# Altium schematic sheet Schematic Prints("All Documents",Physical)
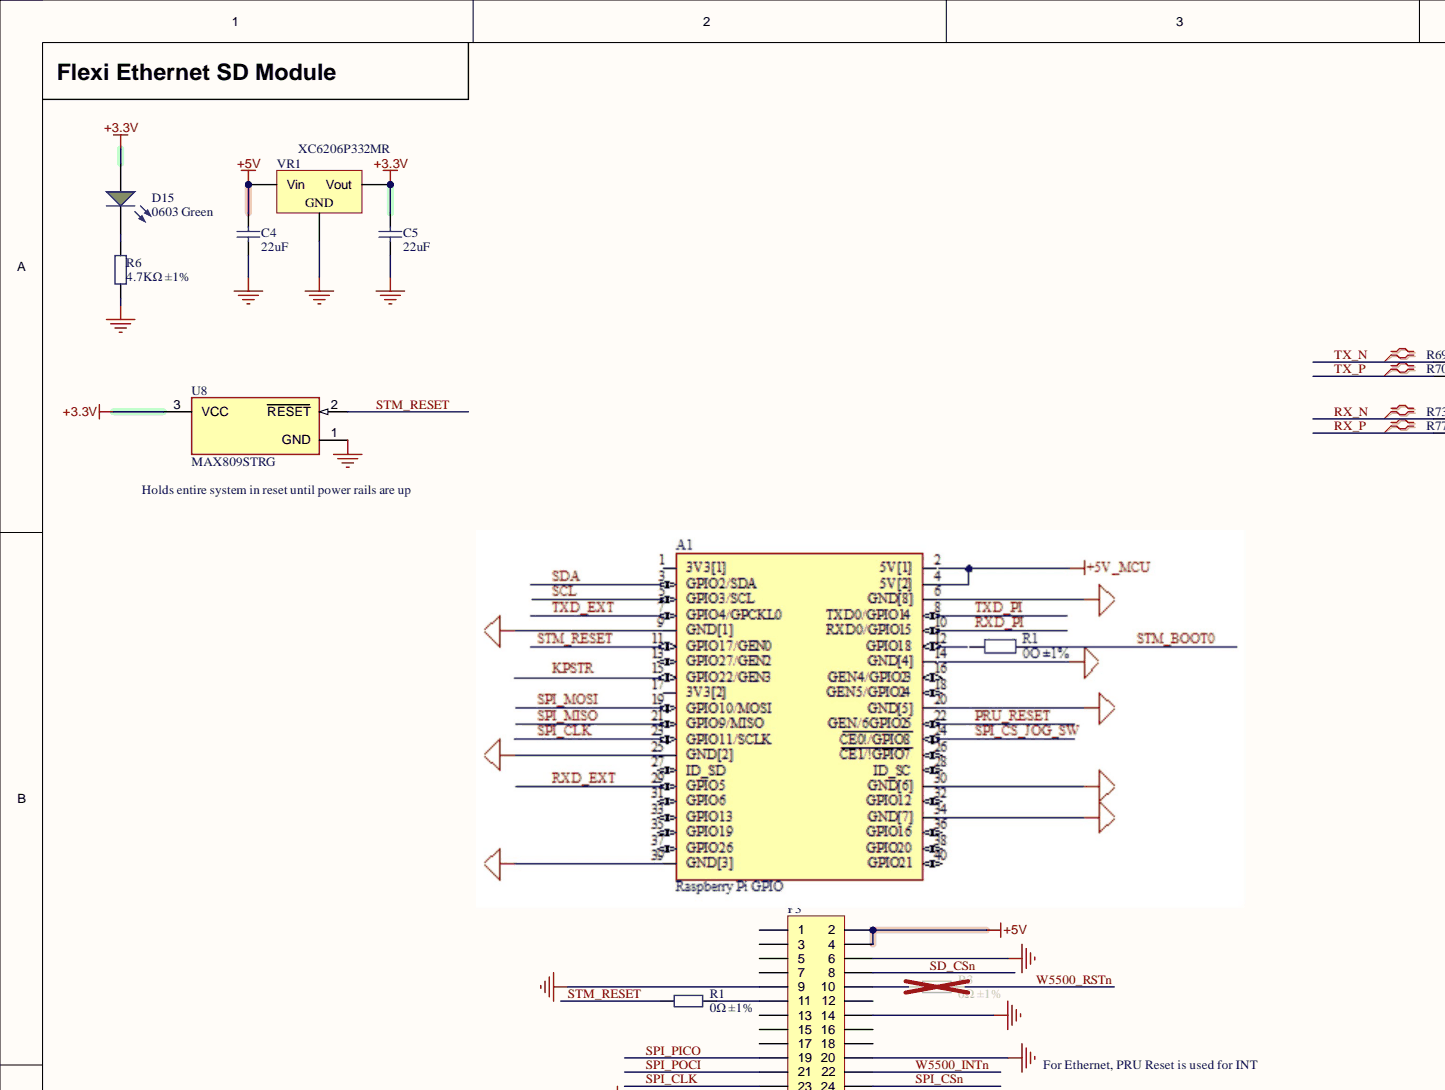
[diagram p0: Schematic Prints("All Documents",Physical), top left]
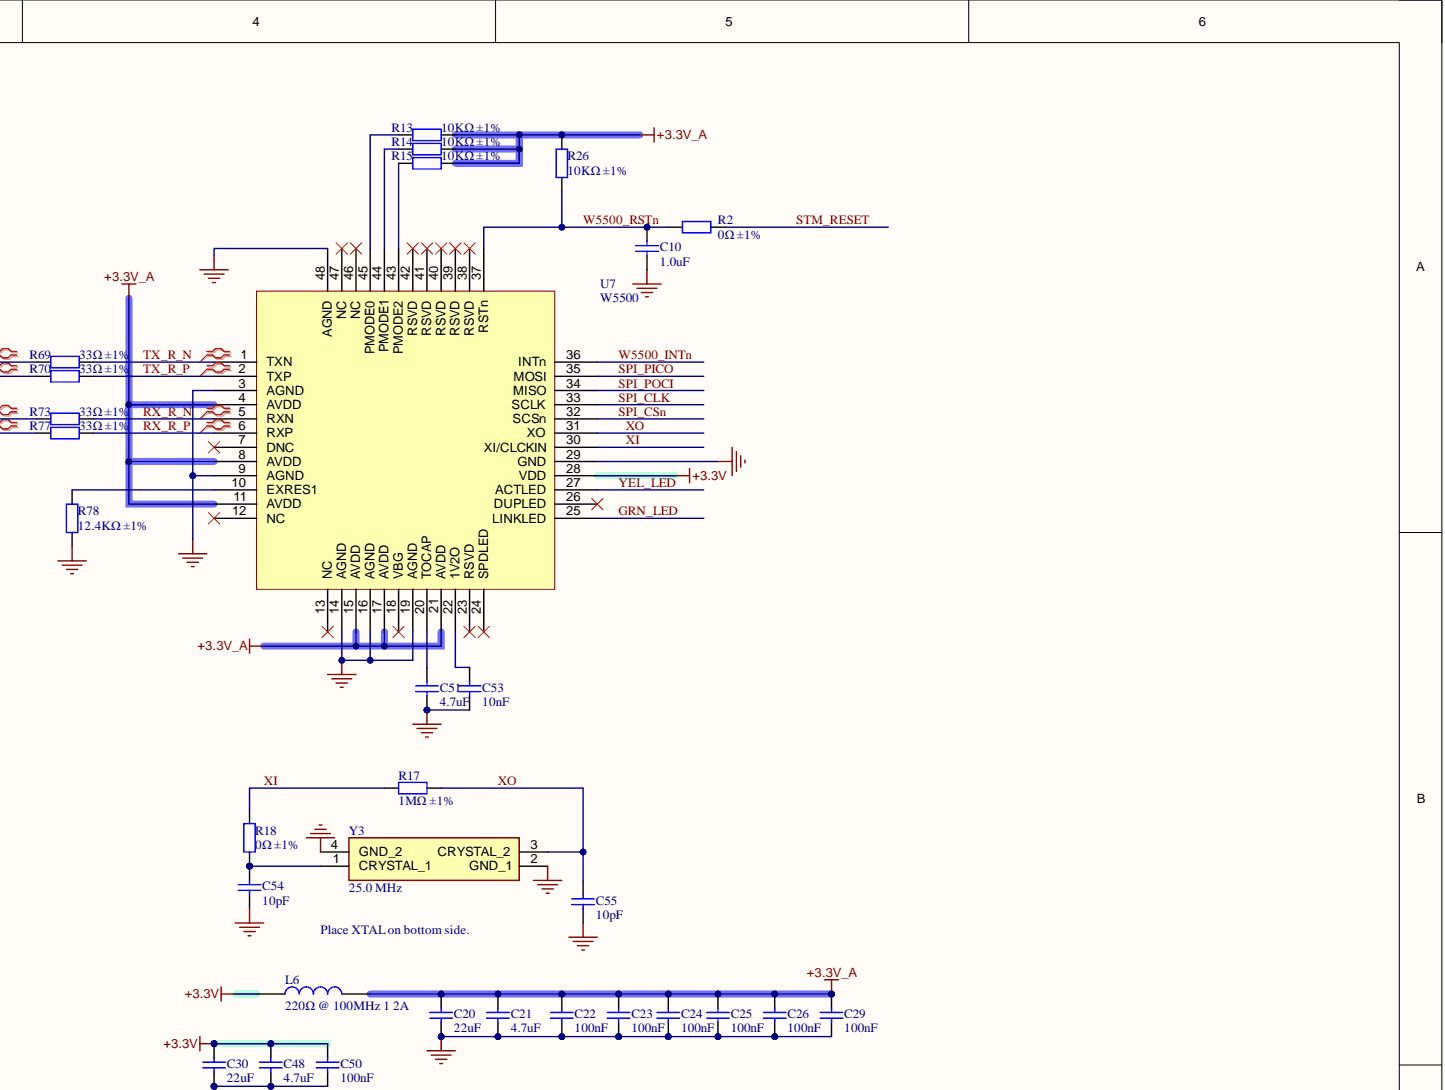
[diagram p1: Schematic Prints("All Documents",Physical), top right]
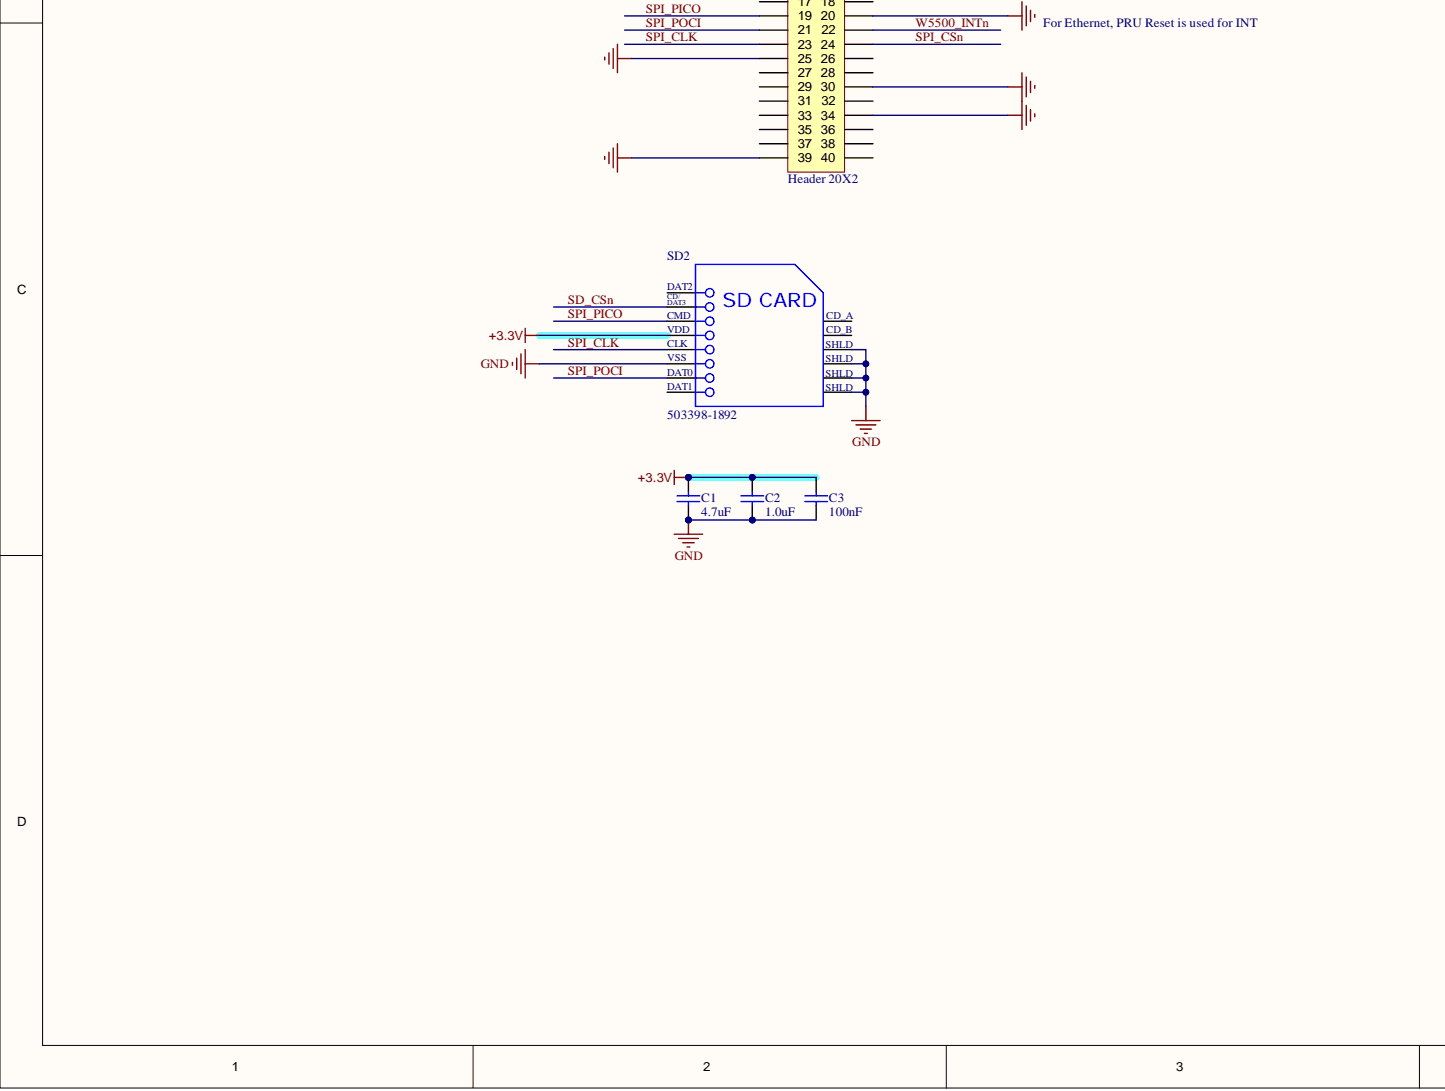
[diagram p2: Schematic Prints("All Documents",Physical), bottom left]
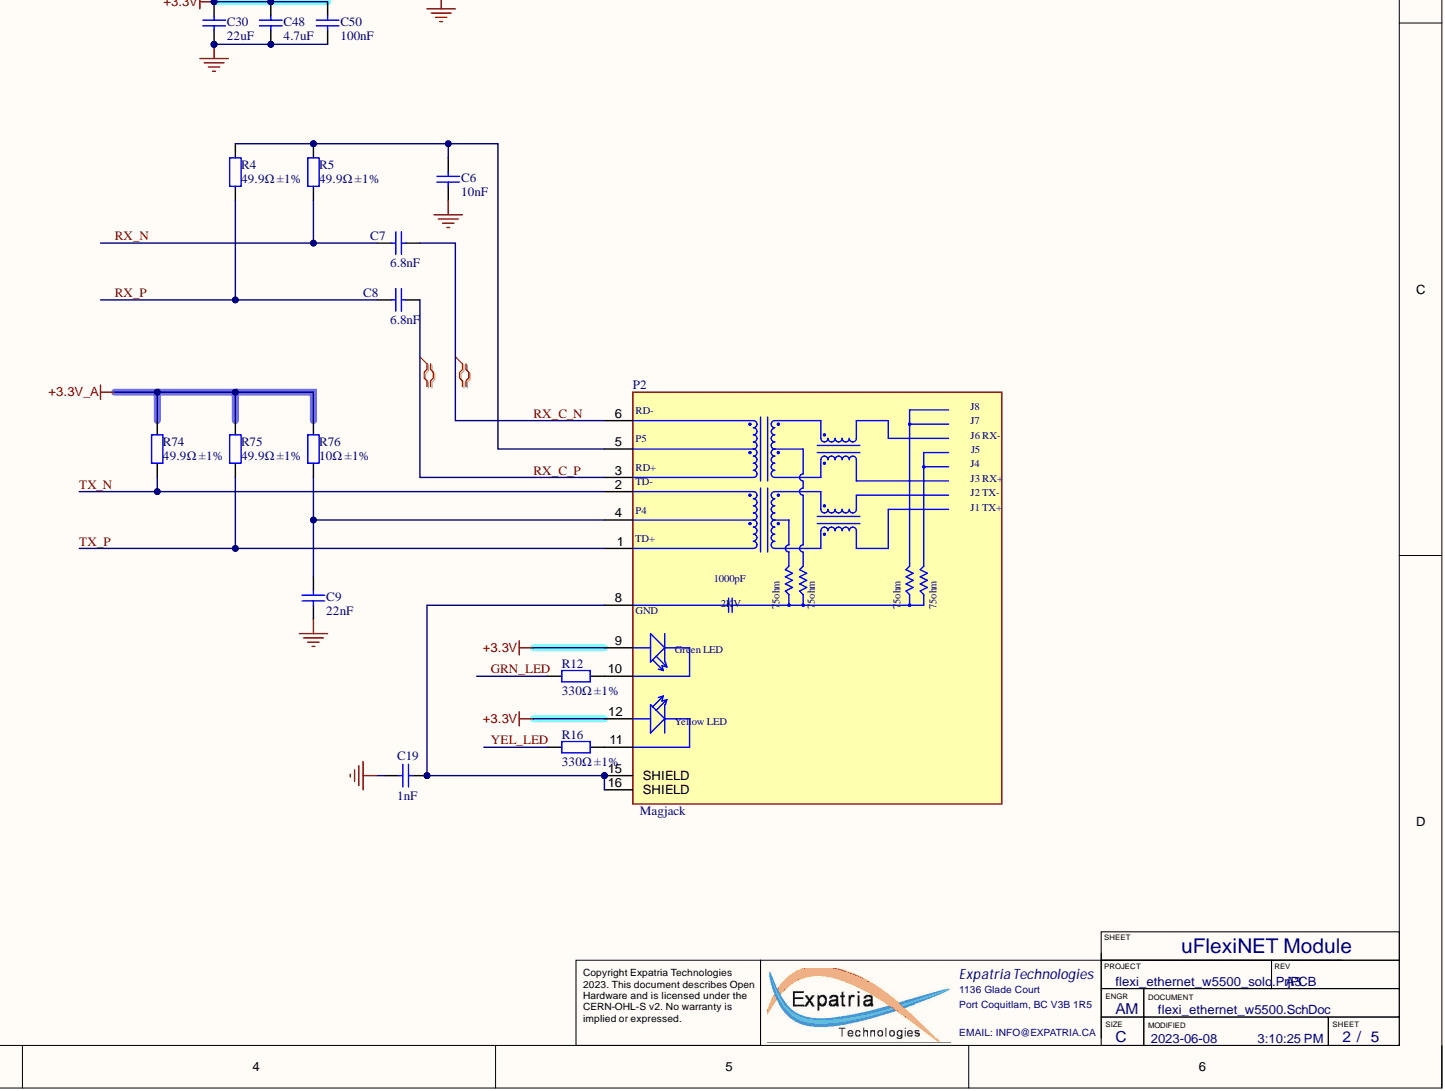
[diagram p3: Schematic Prints("All Documents",Physical), bottom right]

## Parts
C1  4.7uF  [0603_C]
C2  1.0uF  [0402_C]
C3  100nF  [0402_C]
C4  22uF  [0805_C]
C5  22uF  [0805_C]
C6  10nF  [0402_C]
C7  6.8nF  [0402_C]
C8  6.8nF  [0402_C]
C9  22nF  [0402_C]
C10  1.0uF  [0402_C]
C19  1nF  [1206_C]
C20  22uF  [0805_C]
C21  4.7uF  [0603_C]
C22  100nF  [0402_C]
C23  100nF  [0402_C]
C24  100nF  [0402_C]
C25  100nF  [0402_C]
C26  100nF  [0402_C]
C29  100nF  [0402_C]
C30  22uF  [0805_C]
C48  4.7uF  [0603_C]
C50  100nF  [0402_C]
C51  4.7uF  [0402_C]
C53  10nF  [0402_C]
C54  10pF  [0402_C]
C55  10pF  [0402_C]
D15  0603 Green  Color: Green  Package: 0603  [0603_LED_S1]
L6  220Ω @ 100MHz 1 2A  [0805_C]
P2  Magjack  [HANRUN_HR911105A]
P3  Header 20X2  [HDR2X20_2.54_180]
R1  0Ω ±1%  Resistance: 0Ω  [0402_R]
R2  0Ω ±1%  Resistance: 0Ω  [0402_R]
R3  0Ω ±1%  Resistance: 0Ω  [0402_R]
R4  49.9Ω ±1%  Resistance: 49.9Ω  [0402_R]
R5  49.9Ω ±1%  Resistance: 49.9Ω  [0402_R]
R6  4.7KΩ ±1%  Resistance: 4.7KΩ  [0402_R]
R12  330Ω ±1%  Resistance: 330Ω  [0402_R]
R13  10KΩ ±1%  Resistance: 10KΩ  [0402_R]
R14  10KΩ ±1%  Resistance: 10KΩ  [0402_R]
R15  10KΩ ±1%  Resistance: 10KΩ  [0402_R]
R16  330Ω ±1%  Resistance: 330Ω  [0402_R]
R17  1MΩ ±1%  Resistance: 1MΩ  [0402_R]
R18  0Ω ±1%  Resistance: 0Ω  [0402_R]
R26  10KΩ ±1%  Resistance: 10KΩ  [0402_R]
R69  33Ω ±1%  Resistance: 33Ω  [0402_R]
R70  33Ω ±1%  Resistance: 33Ω  [0402_R]
R73  33Ω ±1%  Resistance: 33Ω  [0402_R]
R74  49.9Ω ±1%  Resistance: 49.9Ω  [0402_R]
R75  49.9Ω ±1%  Resistance: 49.9Ω  [0402_R]
R76  10Ω ±1%  Resistance: 10Ω  [0402_R]
R77  33Ω ±1%  Resistance: 33Ω  [0402_R]
R78  12.4KΩ ±1%  [0603_R]
SD2  503398-1892  [MOLX-503398-1892_V]
U7  W5500  [LQFP-48_7x7x05P]
U8  MAX809STRG  [FP-318-08-MFG]
VR1  XC6206P332MR  [SOT-23-3L]
Y3  25.0 MHz  [YSX321SL]

## Nets
+3.3V: C1.2 C2.2 C3.2 C5.2 C30.2 C48.2 C50.2 D15.A L6.1 P2.9 P2.12 SD2.4 U7.28(VDD) U8.3 VR1.2
+3.3V_A: C20.2 C21.2 C22.2 C23.2 C24.2 C25.2 C26.2 C29.2 L6.2 R13.1 R14.1 R15.1 R26.1 R74.1 R75.1 R76.1 U7.4(AVDD) U7.8(AVDD) U7.11(AVDD) U7.15(AVDD) U7.17(AVDD) U7.21(AVDD)
+5V: C4.2 P3.2 P3.4 VR1.3
GND: C1.1 C2.1 C3.1 C4.1 C5.1 C6.1 C9.1 C10.1 C19.1 C20.1 C21.1 C22.1 C23.1 C24.1 C25.1 C26.1 C29.1 C30.1 C48.1 C50.1 C51.1 C53.1 C54.1 C55.1 P3.6 P3.9 P3.14 P3.20 P3.25 P3.30 P3.34 P3.39 R6.1 R78.1 SD2.6 SD2.11 SD2.12 SD2.13 SD2.14 U7.3(AGND) U7.9(AGND) U7.14(AGND) U7.16(AGND) U7.19(AGND) U7.29(GND) U7.48(AGND) U8.1 VR1.1 Y3.2(GND_1) Y3.4(GND_2)
GRN_LED: R12.1 U7.25(LINKLED)
RX_C_N: C7.1 P2.6
RX_C_P: C8.1 P2.3
RX_N: C7.2 R5.2 R73.1
RX_P: C8.2 R4.2 R77.1
RX_R_N: R73.2 U7.5(RXN)
RX_R_P: R77.2 U7.6(RXP)
SD_CSn: P3.8 SD2.2(SD_CARD)
SPI_CLK: P3.23 SD2.5 U7.33(SCLK)
SPI_CSn: P3.24 U7.32(SCSn)
SPI_PICO: P3.19 SD2.3 U7.35(MOSI)
SPI_POCI: P3.21 SD2.7 U7.34(MISO)
STM_RESET: R1.2 R2.1 U8.2
TX_N: P2.2 R69.1 R74.2
TX_P: P2.1 R70.1 R75.2
TX_R_N: R69.2 U7.1(TXN)
TX_R_P: R70.2 U7.2(TXP)
W5500_INTn: P3.22 U7.36(INTn)
W5500_RSTn: C10.2 R2.2 R3.1 R26.2 U7.37(RSTn)
XI: R17.1 R18.1 U7.30(XI/CLCKIN)
XO: C55.2 R17.2 U7.31(XO) Y3.3(CRYSTAL_2)
YEL_LED: R16.1 U7.27(ACTLED)
NetC6_2: C6.2 P2.5 R4.1 R5.1
NetC9_2: C9.2 P2.4 R76.2
NetC19_2: C19.2 P2.8 P2.15(SHIELD) P2.16(SHIELD)
NetC51_2: C51.2 U7.20(TOCAP)
NetC53_2: C53.2 U7.22(1V2O)
NetC54_2: C54.2 R18.2 Y3.1(CRYSTAL_1)
NetD15_K: D15.K R6.2
NetP2_10: P2.10 R12.2
NetP2_11: P2.11 R16.2
NetP3_1: P3.1
NetP3_3: P3.3
NetP3_5: P3.5
NetP3_7: P3.7
NetP3_10: P3.10 R3.2
NetP3_11: P3.11 R1.1
NetP3_12: P3.12
NetP3_13: P3.13
NetP3_15: P3.15
NetP3_16: P3.16
NetP3_17: P3.17
NetP3_18: P3.18
NetP3_26: P3.26
NetP3_27: P3.27
NetP3_28: P3.28
NetP3_29: P3.29
NetP3_31: P3.31
NetP3_32: P3.32
NetP3_33: P3.33
NetP3_35: P3.35
NetP3_36: P3.36
NetP3_37: P3.37
NetP3_38: P3.38
NetP3_40: P3.40
NetR13_2: R13.2 U7.45(PMODE0)
NetR14_2: R14.2 U7.44(PMODE1)
NetR15_2: R15.2 U7.43(PMODE2)
NetR78_2: R78.2 U7.10(EXRES1)
NetSD2_1: SD2.1
NetSD2_8: SD2.8
NetSD2_9: SD2.9
NetSD2_10: SD2.10
NetU7_7: U7.7(DNC)
NetU7_12: U7.12(NC)
NetU7_13: U7.13(NC)
NetU7_18: U7.18(VBG)
NetU7_23: U7.23(RSVD)
NetU7_24: U7.24(SPDLED)
NetU7_26: U7.26(DUPLED)
NetU7_38: U7.38(RSVD)
NetU7_39: U7.39(RSVD)
NetU7_40: U7.40(RSVD)
NetU7_41: U7.41(RSVD)
NetU7_42: U7.42(RSVD)
NetU7_46: U7.46(NC)
NetU7_47: U7.47(NC)

## Library data of the parts
C1 = 0603 4.7uF (475) 10% 16V: Chip capacitors; Manufacturer: Samsung Electro-Mechanics; Manufacturer Part Number: CL10A475KO8NNNC; Tolerance: 10%; Voltage: 16V; Capacitance: 4.7uF; Component Kind: Standard; ComponentLink1Description: Supplier link; ComponentLink1URL: http://www.szlcsc.com/product/details_20375.html; Library Name: Cap.SchLib; Package: 0603; PartNumber: CL10A475KO8NNNC; Supplier: LCSC; SuppliersPartNumber: C19666
C2 C10 = 0402 1.0uF (105) 10% 25V: Chip capacitor; Manufacturer: Samsung Electro-Mechanics; PartNumber: CL05A105KA5NQNC; Capacitance: 1uF; Tolerance: 10%; Voltage: 25V; Component Kind: Standard; ComponentLink1Description: Supplier link; ComponentLink1URL: http://www.szlcsc.com/product/details_53938.html; Library Name: Cap.SchLib; Package: 0402; Supplier: LC; SuppliersPartNumber: C52923
C3 C22-C26 C29 C50 = 0402 100nF (104) 10% 16V: Chip capacitors; Manufacturer: Samsung Electro-Mechanics; PartNumber: CL05B104KO5NNNC; Tolerance: 10%; Voltage: 16V; Capacitance: 100nF; Component Kind: Standard; ComponentLink1Description: Supplier link; ComponentLink1URL: http://www.szlcsc.com/product/details_1877.html; Library Name: Cap.SchLib; Package: 0402; Supplier: LC; SuppliersPartNumber: C1525
C4 C5 C20 C30 = 0805 22uF (226) 20% 25V: Chip capacitors; Manufacturer: Samsung Electro-Mechanics; PartNumber: CL21A226MAQNNNE; Tolerance: 20%; Voltage: 25V; Capacitance: 22uF; Component Kind: Standard; ComponentLink1Description: Supplier link; ComponentLink1URL: http://www.szlcsc.com/product/details_46786.html; Library Name: Cap.SchLib; Package: 0805; Supplier: LC; SuppliersPartNumber: C2922481
C6 C53 = 0402 10nF (103) 10% 50V: Chip capacitors; Manufacturer: Samsung Electro-Mechanics; PartNumber: CL05B103KB5NNNC; Tolerance: 10%; Voltage: 50V; Capacitance: 10nF; Component Kind: Standard; ComponentLink1Description: Supplier link; ComponentLink1URL: http://www.szlcsc.com/product/details_15869.html; Library Name: Cap.SchLib; Package: 0402; Supplier: LC; SuppliersPartNumber: C15195
C7 C8 = 0402 6.8nF (682) 10% 50V: Chip capacitors; Manufacturer: Guangdong Fenghua Advanced Tech; PartNumber: 0402B682K500NT; Tolerance: 10%; Voltage: 50V; Capacitance: 6.8nF; Component Kind: Standard; ComponentLink1Description: Supplier link; ComponentLink1URL: http://www.szlcsc.com/product/details_1894.html; Library Name: Cap.SchLib; Package: 0402; Supplier: LC; SuppliersPartNumber: C1542
C9 = 0402 22nF (223) 10% 50V: Chip capacitors; Manufacturer: Guangdong Fenghua Advanced Tech; PartNumber: 0402B223K500NT; Tolerance: 10%; Voltage: 50V; Capacitance: 22nF; Component Kind: Standard; ComponentLink1Description: Supplier link; ComponentLink1URL: http://www.szlcsc.com/product/details_1884.html; Library Name: Cap.SchLib; Package: 0402; Supplier: LC; SuppliersPartNumber: C1532
C19 = 1206 1nF (102) 10% 500V: Chip capacitors; Manufacturer: Samsung Electro-Mechanics; PartNumber: CL31B102KGFNNNE; Tolerance: 10%; Voltage: 500V; Capacitance: 1nF; Component Kind: Standard; ComponentLink1Description: Supplier link; ComponentLink1URL: http://www.szlcsc.com/product/details_36187.html; Library Name: Cap.SchLib; Package: 1206; Supplier: LC; SuppliersPartNumber: C35216
C21 C48 = 0603 4.7uF (475) 10% 16V: Chip capacitors; Manufacturer: Samsung Electro-Mechanics; PartNumber: CL10A475KO8NNNC; Tolerance: 10%; Voltage: 16V; Capacitance: 4.7uF; Component Kind: Standard; ComponentLink1Description: Supplier link; ComponentLink1URL: http://www.szlcsc.com/product/details_20375.html; Library Name: Cap.SchLib; Package: 0603; Supplier: LC; SuppliersPartNumber: C19666
C51 = 0402 4.7uF (475) 20% 10V: Chip capacitor; Manufacturer: Samsung Electro-Mechanics; PartNumber: CL05A475MP5NRNC; Tolerance: 20%; Voltage: 10V; Capacitance: 4.7uF; Component Kind: Standard; ComponentLink1Description: Supplier link; ComponentLink1URL: http://www.szlcsc.com/product/details_24469.html; Library Name: Cap.SchLib; Package: 0402; Supplier: LC; SuppliersPartNumber: C23733
C54 C55 = 0402 10pF (100) 5% 50V: Chip capacitors; Manufacturer: Samsung Electro-Mechanics; PartNumber: CL05C100JB5NNNC; Tolerance: 5%; Voltage: 50V; Capacitance: 10pF; Component Kind: Standard; ComponentLink1Description: Supplier link; ComponentLink1URL: http://www.szlcsc.com/product/details_33914.html; Library Name: Cap.SchLib; Package: 0402; Supplier: LC; SuppliersPartNumber: C32949
D15 = 0603 LED Green: LED; Component Kind: Standard; ComponentLink1Description: 供应商链接; ComponentLink1URL: http://www.szlcsc.com/product/details_73152.html; Library Name: LED.SchLib; Supplier: LC; SuppliersPartNumber: C72043
L6 = 0402 220R@100Mhz 0.1A: 220Ω @ 100MHz 1 2A 150mΩ 0805 Ferrite Beads ROHS; Component Kind: Standard; Package: 0805; Supplier: LC; SuppliersPartNumber: C139188; Library Name: FBEAD.SchLib
P2 = HR911105A: HR911105A Ethernet Interface Arduino Platform Evaluation Expansion Board; MF: DFRobot; Availability: Unavailable; Component Kind: Standard; MP: HR911105A; Package: None; Price: None; SuppliersPartNumber: C163507; Library Name: PrintNC_GRBLHAL_Breakout.SCHLIB
P3 = Header 20X2: Header, 20-Pin, Dual row; Component Kind: Standard; LatestRevisionDate: 17-Jul-2002; LatestRevisionNote: Re-released for DXP Platform.; Library Name: Miscellaneous Connectors.IntLib; Signal Integrity: Connector; SuppliersPartNumber: C124383; Publisher: Altium Limited
R1-R3 R18 = 0402 0R (0R0) 1%: Chip resistor; Manufacturer: Uniroyal Elec; PartNumber: 0402WGF0000TCE; Power: 1/16W; Component Kind: Standard; ComponentLink1Description: Supplier link; ComponentLink1URL: http://www.szlcsc.com/product/details_17853.html; Library Name: RES.SchLib; Package: 0402; Supplier: LC; SuppliersPartNumber: C17168; Tolerance: 1%
R4 R5 R74 R75 = 0402 49.9R (49R9) 1%: Chip resistor; Manufacturer: Uniroyal Elec; PartNumber: 0402WGF499JTCE; Power: 1/16W; Component Kind: Standard; ComponentLink1Description: Supplier link; ComponentLink1URL: http://www.szlcsc.com/product/details_25863.html; Library Name: RES.SchLib; Package: 0402; Supplier: LC; SuppliersPartNumber: C25120; Tolerance: 1%
R6 = 0402 4.7K (4701) 1%: Chip resistor; Manufacturer: Uniroyal Elec; PartNumber: 0402WGF4701TCE; Power: 1/16W; Component Kind: Standard; ComponentLink1Description: Supplier link; ComponentLink1URL: http://www.szlcsc.com/product/details_26643.html; Library Name: RES.SchLib; Package: 0402; Supplier: LC; SuppliersPartNumber: C25900; Tolerance: 1%
R12 R16 = 0402 330R (3300) 1%: Chip resistor; Manufacturer: Uniroyal Elec; PartNumber: 0402WGF3300TCE; Power: 1/16W; Component Kind: Standard; ComponentLink1Description: Supplier link; ComponentLink1URL: http://www.szlcsc.com/product/details_25847.html; Library Name: RES.SchLib; Package: 0402; Supplier: LC; SuppliersPartNumber: C25104; Tolerance: 1%
R13-R15 R26 = 0402 10K (1002) 1%: Chip resistor; Manufacturer: Uniroyal Elec; PartNumber: 0402WGF1002TCE; Power: 1/16W; Component Kind: Standard; ComponentLink1Description: Supplier link; ComponentLink1URL: http://www.szlcsc.com/product/details_26487.html; Library Name: RES.SchLib; Package: 0402; Supplier: LC; SuppliersPartNumber: C25744; Tolerance: 1%
R17 = 0402 1M (1004) 1%: Chip resistor; Manufacturer: Uniroyal Elec; PartNumber: 0402WGF1004TCE; Power: 1/16W; Component Kind: Standard; ComponentLink1Description: Supplier link; ComponentLink1URL: http://www.szlcsc.com/product/details_26826.html; Library Name: RES.SchLib; Package: 0402; Supplier: LC; SuppliersPartNumber: C26083; Tolerance: 1%
R69 R70 R73 R77 = 0402 33R (33R0) 1%: Chip resistor; Manufacturer: Uniroyal Elec; PartNumber: 0402WGF330JTCE; Power: 1/16W; Component Kind: Standard; ComponentLink1Description: Supplier link; ComponentLink1URL: http://www.szlcsc.com/product/details_25848.html; Library Name: RES.SchLib; Package: 0402; Supplier: LC; SuppliersPartNumber: C25105; Tolerance: 1%
R76 = 0402 10R (10R0) 1%: Chip resistor; Manufacturer: Uniroyal Elec; PartNumber: 0402WGF100JTCE; Power: 1/16W; Component Kind: Standard; ComponentLink1Description: Supplier link; ComponentLink1URL: http://www.szlcsc.com/product/details_25820.html; Library Name: RES.SchLib; Package: 0402; Supplier: LC; SuppliersPartNumber: C25077; Tolerance: 1%
R78 = 0603 10K (1002) 1%: Chip resistor; Manufacturer: Uniroyal Elec; PartNumber: 0603WAF1242T5E; Power: 1/10W; Resistance: 12.4K; Component Kind: Standard; ComponentLink1Description: Supplier link; ComponentLink1URL: http://www.szlcsc.com/product/details_26547.html; Library Name: RES.SchLib; Package: 0603; Supplier: LC; SuppliersPartNumber: C22865; Tolerance: 1%
SD2 = CMP-2000-05621-1: Micro SD Connector, Pitch 1.1 mm, 8 Position, Height 1.4 mm, -25 to 85 degC, RoHS, Tape and Reel; Brand: Molex; Voltage Rating: 10VAC/DC; Component Kind: Standard; Library Name: Altium Content Vault; Manufacturer: Molex; Manufacturer URL: http://www.molex.com/; Package Reference: 503398-1892; Packaging: Reel; RoHS: Details; Series: 503398; SuppliersPartNumber: C428492
U7 = W5500_[C32843]: Ethernet Controllers 2.97V ~ 3.63V 10/100 Base-T/TX PHY SPI LQFP-48_7x7x05P Ethernet ICs ROHS; Component Kind: Standard; ComponentLink1Description: 供应商链接; ComponentLink1URL: http://www.szlcsc.com/product/details_33808.html; Library Name: IC.SchLib; Package: LQFP-48_7x7x05P; Supplier: LC; SuppliersPartNumber: C32843
U8 = CMP-07130-000007-1: IC MPU SUPERVISORY 2.93V SOT23; Manufacturer: ON SEMICONDUCTOR; Max Junction Temp: +125°C; Max Supply Voltage: 5.5V; Min Supply Voltage: 1V; Nominal Supply Current: 0.5-0.8uA; Number Of Outputs: 1; Output Current: 20mA; Output Voltage: 0.3V; Reset Timeout: 10us; Reset Type: Active Low; Standoff Height: 0.01mm; Automotive: No; Category: IC; Component Kind: Standard; ComponentLink2Description: Datasheet; ComponentLink2URL: https://www.onsemi.cn/PowerSolutions/document/MAX809S-D.PDF; Device Class L1: Integrated Circuits (ICs); Device Class L2: Power Management ICs; Device Class L3: Voltage Supervisors; Digikey Description: IC MPU SUPERVISORY 2.93V SOT23; Footprint Url: https://www.onsemi.com/pub/Collateral/318-08.PDF; Height: 1.11mm; Lead Free: Yes; Library Name: Altium Content Vault; Manufacturer Part Number: MAX809STRG; Mouser Description: Supervisory Circuits 2.93V uP Reset; Number Of Rails Monitored: 1; Octopart: https://octopart.com/max809strg-on+semiconductor-509853; Package: 318-08; RoHS: TRUE; SuppliersPartNumber: C9965; Temperature: -40°C to +125°C; Temperature Range High: +125°C; Temperature Range Low: -40°C; Threshold Voltage: 2.93V
VR1 = SOT-23 XC6206P332MR: 200mA 680mV@(100mA) Fixed 3.3V~3.3V Positive 1 6V SOT-23-3L Linear Voltage Regulators (LDO) ROHS; Component Kind: Standard; ComponentLink1Description: 供应商链接; ComponentLink1URL: http://www.szlcsc.com/product/details_5896.html; Library Name: LDO.SchLib; Package: SOT-23; Supplier: LC; SuppliersPartNumber: C5446
Y3 = YSX321SL: Crystal or Oscillator; Manufacturer_Name: Yangxing Tech; Manufacturer_Part_Number: X322525MSB4SI; Component Kind: Standard; Height: 0.8mm; SuppliersPartNumber: C13740
